# Altium schematic sheet BC26_OPEN_POWER_DISPLAY.SchDoc(BC26_OPEN_POWER_DISPLAY)
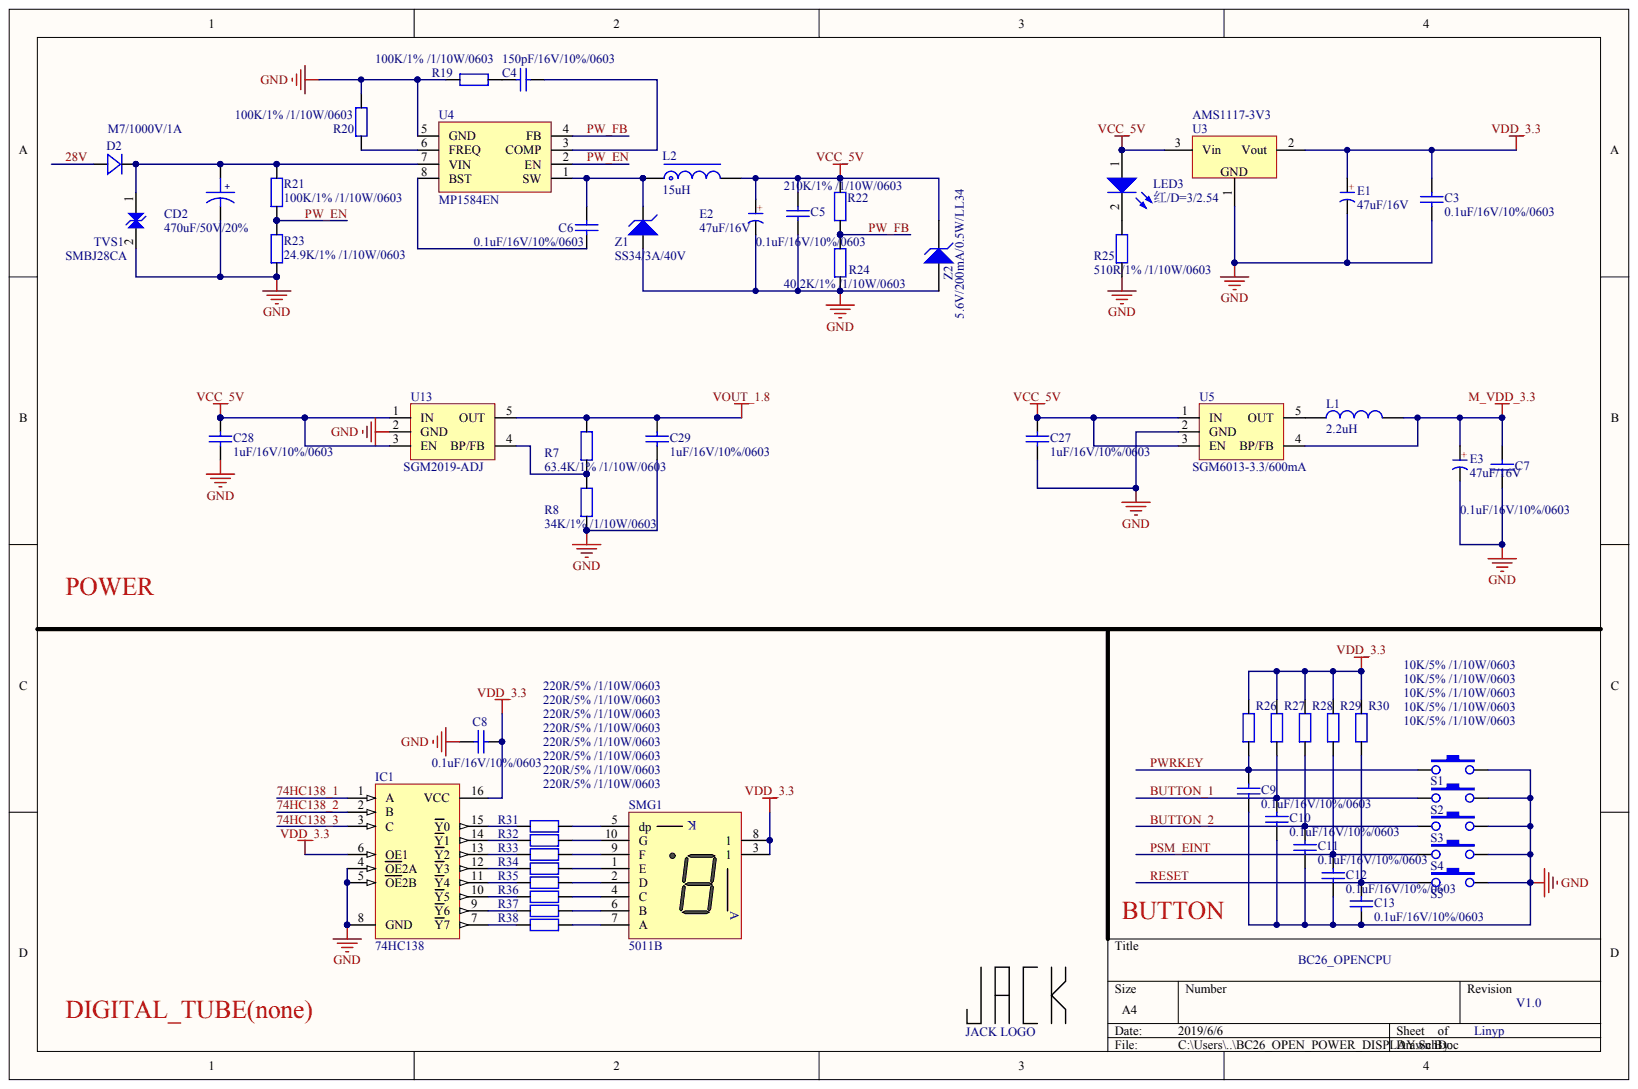
[diagram p0: BC26_OPEN_POWER_DISPLAY.SchDoc]

## Parts
C3  0.1uF/16V/10%/0603
C4  150pF/16V/10%/0603
C5  0.1uF/16V/10%/0603
C6  0.1uF/16V/10%/0603
C7  0.1uF/16V/10%/0603
C8  0.1uF/16V/10%/0603
C9  0.1uF/16V/10%/0603
C10  0.1uF/16V/10%/0603
C11  0.1uF/16V/10%/0603
C12  0.1uF/16V/10%/0603
C13  0.1uF/16V/10%/0603
C27  1uF/16V/10%/0603
C28  1uF/16V/10%/0603
C29  1uF/16V/10%/0603
CD2  470uF/50V/20%
D2  M7/1000V/1A
E1  47uF/16V
E2  47uF/16V
E3  47uF/16V
IC1  74HC138
L1  2.2uH
L2  15uH
LED3  红/D=3/2.54
LOGO1  JACK LOGO
R7  63.4K/1% /1/10W/0603
R8  34K/1% /1/10W/0603
R19  100K/1% /1/10W/0603
R20  100K/1% /1/10W/0603
R21  100K/1% /1/10W/0603
R22  210K/1% /1/10W/0603
R23  24.9K/1% /1/10W/0603
R24  40.2K/1% /1/10W/0603
R25  510R/1% /1/10W/0603
R26  10K/5% /1/10W/0603
R27  10K/5% /1/10W/0603
R28  10K/5% /1/10W/0603
R29  10K/5% /1/10W/0603
R30  10K/5% /1/10W/0603
R31  220R/5% /1/10W/0603
R32  220R/5% /1/10W/0603
R33  220R/5% /1/10W/0603
R34  220R/5% /1/10W/0603
R35  220R/5% /1/10W/0603
R36  220R/5% /1/10W/0603
R37  220R/5% /1/10W/0603
R38  220R/5% /1/10W/0603
S1  SW-PB  (value not drawn)
S2  SW-PB  (value not drawn)
S3  SW-PB  (value not drawn)
S4  SW-PB  (value not drawn)
S5  SW-PB  (value not drawn)
SMG1  5011B
TVS1  SMBJ28CA
U3  AMS1117-3V3
U4  MP1584EN
U5  SGM6013-3.3/600mA
U13  SGM2019-ADJ
Z1  SS34/3A/40V
Z2  5.6V/200mA/0.5W/LL34

## Nets
GND: C3.2 C5.2 C7.2 C8.1 C9.2 C10.2 C11.2 C12.2 C13.2 C27.1 C28.2 C29.1 CD2.2 E1.2 E2.2 E3.2 IC1.4(OE2A) IC1.5(OE2B) IC1.8(GND) R8.1 R19.1 R20.2 R23.1 R24.1 R25.1 S1.3 S2.3 S3.3 S4.3 S5.3 TVS1.2 U3.1 U4.5(GND) U5.2(GND) U13.2(GND) Z1.1 Z2.1
M_VDD_3.3: C7.1 E3.1 L1.2 U5.4(BP/FB)
VCC_5V: C5.1 C27.2 C28.1 E2.1 L2.2 LED3.1 R22.2 U3.3 U5.1(IN) U5.3(EN) U13.1(IN) U13.3(EN) Z2.2
VDD_3.3: C3.1 C8.2 E1.1 IC1.6(OE1) IC1.16(VCC) R26.2 R27.2 R28.2 R29.2 R30.2 SMG1.3 SMG1.8 U3.2
VOUT_1.8: C29.2 R7.2 U13.5(OUT)
28V: D2.1
74HC138_1: IC1.1(A)
74HC138_2: IC1.2(B)
74HC138_3: IC1.3(C)
BUTTON_1: C10.1 R27.1 S2.1
BUTTON_2: C11.1 R28.1 S3.1
PSM_EINT: C12.1 R29.1 S4.1
PW_EN: R21.1 R23.2 U4.2(EN)
PW_FB: R22.1 R24.2 U4.4(FB)
PWRKEY: C9.1 R26.1 S1.1
RESET: C13.1 R30.1 S5.1
NetC4_1: C4.1 U4.3(COMP)
NetC4_2: C4.2 R19.2
NetC6_1: C6.1 L2.1 U4.1(SW) Z1.2
NetC6_2: C6.2 U4.8(BST)
NetCD2_1: CD2.1 D2.2 R21.2 TVS1.1 U4.7(VIN)
NetIC1_7: IC1.7(Y7) R38.2
NetIC1_9: IC1.9(Y6) R37.2
NetIC1_10: IC1.10(Y5) R36.2
NetIC1_11: IC1.11(Y4) R35.2
NetIC1_12: IC1.12(Y3) R34.2
NetIC1_13: IC1.13(Y2) R33.2
NetIC1_14: IC1.14(Y1) R32.2
NetIC1_15: IC1.15(Y0) R31.2
NetL1_1: L1.1 U5.5(OUT)
NetLED3_2: LED3.2 R25.2
NetR7_1: R7.1 R8.2 U13.4(BP/FB)
NetR20_1: R20.1 U4.6(FREQ)
NetR31_1: R31.1 SMG1.5(dp)
NetR32_1: R32.1 SMG1.10(G)
NetR33_1: R33.1 SMG1.9(F)
NetR34_1: R34.1 SMG1.1(E)
NetR35_1: R35.1 SMG1.2(D)
NetR36_1: R36.1 SMG1.4(C)
NetR37_1: R37.1 SMG1.6(B)
NetR38_1: R38.1 SMG1.7(A)

## Library data of the parts
E1-E3 = (no symbol name): BOM名称: 贴片钽电容; 版权归属: 源创客; 学习资源: www.ooocke.com
IC1 = (no symbol name): 版权归属: 源创客; BOM名称: 138译码器; 学习资源: www.ooocke.com
L1 = (no symbol name): BOM名称: 小功率贴片电感; 版权归属: 源创客; 学习资源: www.ooocke.com
L2 = (no symbol name): BOM名称: 功率电感; 版权归属: 源创客; 学习资源: www.ooocke.com
SMG1 = (no symbol name): BOM名称: 0.5寸1位共阳; 版权归属: 源创客; 学习资源: www.ooocke.com
U3 = (no symbol name): BOM名称: 稳压芯片; 版权归属: 源创客; 学习资源: www.ooocke.com
C3-C13 C27-C29 CD2 D2 LED3 LOGO1 R7 R8 R19-R38 S1-S5 TVS1 U4 U5 U13 Z1 Z2 = (no symbol name)
  C3-C13 C27-C29: Published: 8-Jun-2000; LatestRevisionDate: 17-Jul-2002; LatestRevisionNote: Re-released for DXP Platform.; PackageReference: RAD-0.3
  LED3: Published: 8-Jun-2000; LatestRevisionDate: 08-Jul-2005; LatestRevisionNote: Mech Layer 1 removed.; PackageReference: LED-0
  Z1 Z2: Published: 8-Jun-2000; LatestRevisionDate: 17-Jul-2002; LatestRevisionNote: Re-released for DXP Platform.; PackageReference: DIODE-0.7; PackageDescription: Diode, Thru-Hole; 2 Leads; 0.7 in Pin Spacing
  C3-C13 C27-C29 LED3 Z1 Z2: Publisher: Altium Limited
